# Altium schematic sheet Power.SchDoc
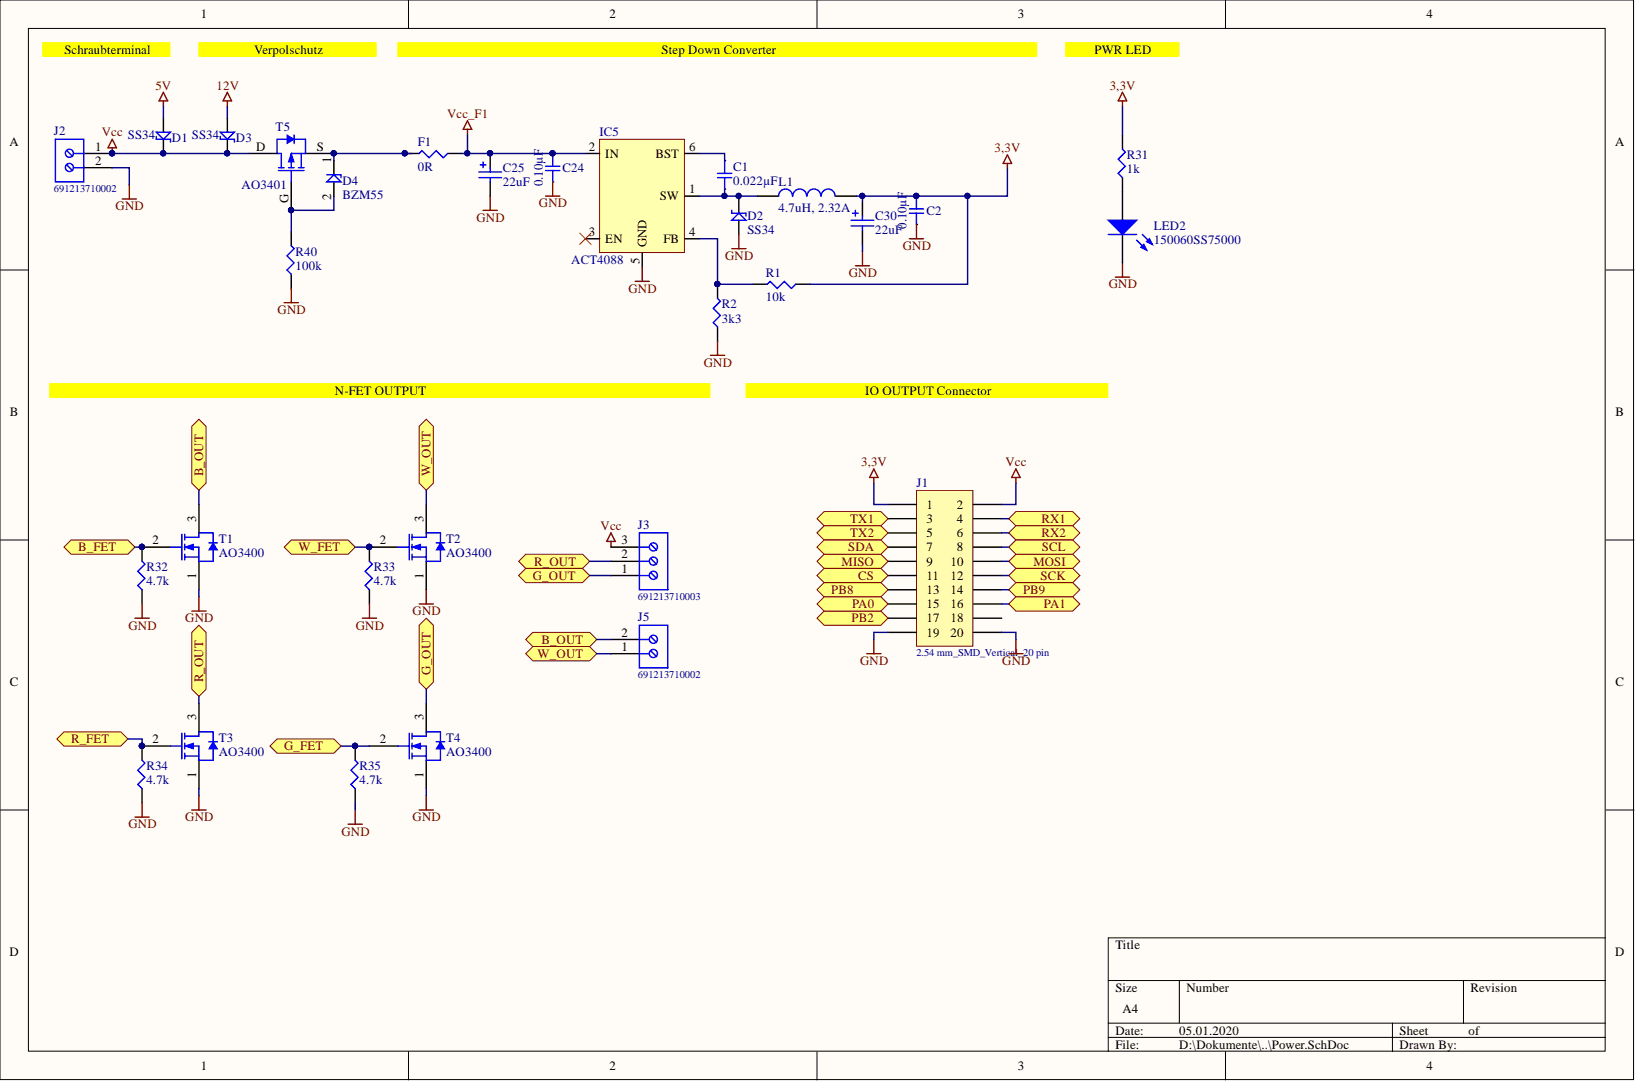
[diagram p0: Power.SchDoc]

## Parts
C1  0.022µF  [SMD-0603C]
C2  0.10µF  [SMD-0603C]
C24  0.10µF  [SMD-0603C]
C25  value 22uF  [WCAP-ASLI_4x5.5]  (hidden comment: 865080140001)
C30  value 22uF  [WCAP-ASLI_4x5.5]  (hidden comment: 865080140001)
D1  SS34  [B240A]
D2  SS34  [B240A]
D3  SS34  [B240A]
D4  BZM55  [SMD-0603-RES]
F1  0R  [SMD-0603-RES]
IC5  ACT4088  [SOT23-6]
J1  2.54 mm_SMD_Vertical_20 pin  [61002021121]
J2  691213710002  [691213710002]
J3  691213710003  [691213710003]
J5  691213710002  [691213710002]
L1  4.7uH, 2.32A  Rated Current: 2.32A  [WE-PD_7332]
LED2  150060SS75000  [0603_A]
R1  10k  [SMD-0603-RES]
R2  3k3  [SMD-0603-RES]
R31  1k  [SMD-0603-RES]
R32  4.7k  [SMD-0603-RES]
R33  4.7k  [SMD-0603-RES]
R34  4.7k  [SMD-0603-RES]
R35  4.7k  [SMD-0603-RES]
R40  100k  [SMD-0603-RES]
T1  AO3400  [SOT-23-3L]
T2  AO3400  [SOT-23-3L]
T3  AO3400  [SOT-23-3L]
T4  AO3400  [SOT-23-3L]
T5  AO3401  [SOT23]

## Ports
B_FET, B_OUT, CS, G_FET, G_OUT, MISO, MOSI, PA0, PA1, PB2, PB8, PB9, R_FET, R_OUT, RX1, RX2, SCK, SCL, SDA, TX1, TX2, W_FET, W_OUT

## Nets
3,3V: C2.1 C30.1 J1.1 L1.2 R1.1 R31.2
5V: D1.A
12V: D3.A
GND: C2.2 C24.2 C25.2 C30.2 D2.A IC5.5(GND) J1.19 J1.20 J2.2 LED2.1 R2.1 R32.1 R33.1 R34.1 R35.1 R40.1 T1.1 T2.1 T3.1 T4.1
Vcc: D1.K D3.K J1.2 J2.1 J3.3 T5.D
Vcc_F1: C24.1 C25.1 F1.1 IC5.2(IN)
B_FET: R32.2 T1.2 ; port B_FET
B_OUT: J5.2 T1.3 ; port B_OUT
CS: J1.11 ; port CS
G_FET: R35.2 T4.2 ; port G_FET
G_OUT: J3.1 T4.3 ; port G_OUT
MISO: J1.9 ; port MISO
MOSI: J1.10 ; port MOSI
PA0: J1.15 ; port PA0
PA1: J1.16 ; port PA1
PB2: J1.17 ; port PB2
PB8: J1.13 ; port PB8
PB9: J1.14 ; port PB9
R_FET: R34.2 T3.2 ; port R_FET
R_OUT: J3.2 T3.3 ; port R_OUT
RX1: J1.4 ; port RX1
RX2: J1.6 ; port RX2
SCK: J1.12 ; port SCK
SCL: J1.8 ; port SCL
SDA: J1.7 ; port SDA
TX1: J1.3 ; port TX1
TX2: J1.5 ; port TX2
W_FET: R33.2 T2.2 ; port W_FET
W_OUT: J5.1 T2.3 ; port W_OUT
NetC1_1: C1.1 IC5.6(BST)
NetC1_2: C1.2 D2.K IC5.1(SW) L1.1
NetD4_1: D4.1 F1.2 T5.S
NetD4_2: D4.2 R40.2 T5.G
NetIC5_4: IC5.4(FB) R1.2 R2.2
NetLED2_2: LED2.2 R31.1

Not connected: IC5 3(EN); J1 18

## Library data of the parts
C1 = GCJ188R71H223KA01D: CAP CER 0.022UF 50V X7R 0603; Manufacturer: Murata Electronics North America; @ qty: 0; Minimum Quantity: 1; Tolerance: ±10%; Unit Price (USD): 0.22; Applications: Automotive, Boardflex Sensitive; Component Kind: Standard; Datasheets: http://www.murata.com/~/media/webrenewal/support/library/catalog/products/capacitor/mlcc/c03e.ashx; Digi-Key Part Number: 490-5851-1-ND; Factory Stock: 0; Features: Soft Termination; Height - Seated (Max): -; Image: //media.digikey.com/Renders/Murata%20Renders/0603%28LQG18%29.jpg; Lead Spacing: -; Lead Style: -; Library Name: capacitor_murata_0603.IntLib; Mounting Type: Surface Mount, MLCC; Operating Temperature: -55°C ~ 125°C; Package / Case: 0603 (1608 Metric); Packaging: Cut Tape (CT); Part Number: GCJ188R71H223KA01D; Part Status: Active; Quantity Available: 7651; Ratings: AEC-Q200; Series: GCJ; Size / Dimension: 0.063" L x 0.031" W (1.60mm x 0.80mm); Temperature Coefficient: X7R; Thickness (Max): 0.031" (0.80mm); Value: 0.022µF; Voltage: 50V
C2 C24 = GCM188R71C104KA37J: CAP CER 0.1UF 16V X7R 0603; Manufacturer: Murata Electronics North America; @ qty: 0; Minimum Quantity: 1; Tolerance: ±10%; Unit Price (USD): 0.18; Applications: Automotive; Component Kind: Standard; Datasheets: http://www.murata.com/~/media/webrenewal/support/library/catalog/products/capacitor/mlcc/c03e.ashx; Digi-Key Part Number: 490-8015-1-ND; Factory Stock: 0; Features: -; Height - Seated (Max): -; Image: //media.digikey.com/Renders/Murata%20Renders/0603%28GCM188%29.jpg; Lead Spacing: -; Lead Style: -; Library Name: capacitor_murata_0603.IntLib; Mounting Type: Surface Mount, MLCC; Operating Temperature: -55°C ~ 125°C; Package / Case: 0603 (1608 Metric); Packaging: Cut Tape (CT); Part Number: GCM188R71C104KA37J; Part Status: Active; Quantity Available: 17844; Ratings: AEC-Q200; Series: GCM; Size / Dimension: 0.063" L x 0.031" W (1.60mm x 0.80mm); Temperature Coefficient: X7R; Thickness (Max): 0.035" (0.90mm); Value: 0.10µF; Voltage: 16V
C25 C30 = 865080140001: WCAP-ASLI Aluminum Electrolytic Capacitors, size Ф4x5.5mm, 6.3V DC, 22uF; Rated Voltage: 6.3V DC; Component Kind: Standard; ComponentLink1Description: Manufacturer; ComponentLink1URL: http://www.we-online.de; ComponentLink2Description: Datasheet; ComponentLink2URL: http://katalog.we-online.de/pbs/datasheet/865080140001.pdf; Library Name: ALTIUM-WCAP-ASLI-rev17a.IntLib; Life Cycle: 2000h; Operating Temperature: -55 °C up to +105 °C; Part Number: 865080140001; Published Date: June 2017; Size: Ф4x5.5mm; Publisher: Wurth Elektronik
D1-D3 = B240A: Shottkydiode; Component Kind: Standard; Library Name: MyLibs.SchLib
F1 R1 R2 R31 = ERJ-3EKF1001V: RES SMD 1K OHM 1% 1/10W 0603; Manufacturer: Panasonic Electronic Components; @ qty: 1500; Factory Stock: 0; Minimum Quantity: 1; Power (Watts): 0.1W, 1/10W; Temperature Coefficient: ±100ppm/°C; Unit Price (USD): 0.00379; Component Kind: Standard; Composition: Thick Film; Datasheets: http://industrial.panasonic.com/www-cgi/jvcr13pz.cgi?E+PZ+3+AOA0002+ERJ3EKF1001V+7+WW; Digi-Key Part Number: P1.00KHCT-ND; Failure Rate: -; Features: Automotive AEC-Q200; Height - Seated (Max): 0.022" (0.55mm); Image: //media.digikey.com/Photos/Panasonic%20Photos/MFG_ERJ-P6W%20Series.jpg; Library Name: Panasonic_ERJ_0603.IntLib; Number of Terminations: 2; Operating Temperature: -55°C ~ 155°C; Package / Case: 0603 (1608 Metric); Packaging: Cut Tape (CT); Part Number: ERJ-3EKF1001V; Part Status: Active; Quantity Available: 5218845; Series: ERJ; Size / Dimension: 0.063" L x 0.031" W (1.60mm x 0.80mm); Supplier Device Package: 0603; Tolerance: ±1%
  F1: Value: 0R
  R1: Value: 10k
  R2: Value: 3k3
  R31: Value: 1k
J1 = 61002021121: Pin Header WR-PHD, pitch 2.54 mm, SMD, Vertical, dual row, 20 pin; Contact Resistance: 20 mOhm; Insulation Resistance: >1000 MOhm; Pin Number: 20; Pitch: 2.54 mm; Rated Current: 3 A; Working Voltage: 250 VAC; Component Kind: Standard; ComponentLink1Description: Manufacturer; ComponentLink1URL: http://www.we-online.de; ComponentLink2Description: Datasheet; ComponentLink2URL: http://katalog.we-online.de/em/datasheet/6100xx21121.pdf; Library Name: Altium_WR-PHD_PIN_HEADER_2_54 (rev18a).IntLib; Mounting Technology: SMD; Operating Temperature: -40 to 105 degC; Orientation: Vertical; Part Number: 61002021121; Published Date: Jan. 2018; Row Number: Dual; Publisher: Wurth Elektronik
J2 J5 = 691213710002: Serie 213 - 5.00 mm Horizontal Entry Modular with RisingCage Clamp WR-TBL, 2 pin; Pin Number: 2; Pitch: 5.00 mm; Component Kind: Standard; ComponentLink1Description: Manufacturer; ComponentLink1URL: http://www.we-online.de; ComponentLink2Description: Datasheet; ComponentLink2URL: http://katalog.we-online.de/em/datasheet/6912137100xx.pdf; Library Name: Altium_TBL_RISING_CAGE_SYSTEM (rev18b).IntLib; Mounting Technology: THT; Operating Temperature: -40 to 105 degC; Packaging: Box; Part Number: 691213710002; Published Date: June 2018; Publisher: Wurth Elektronik
J3 = 691213710003: Serie 213 - 5.00 mm Horizontal Entry Modular with RisingCage Clamp WR-TBL, 3 pin; Pin Number: 3; Pitch: 5.00 mm; Component Kind: Standard; ComponentLink1Description: Manufacturer; ComponentLink1URL: http://www.we-online.de; ComponentLink2Description: Datasheet; ComponentLink2URL: http://katalog.we-online.de/em/datasheet/6912137100xx.pdf; Library Name: Altium_TBL_RISING_CAGE_SYSTEM (rev18b).IntLib; Mounting Technology: THT; Operating Temperature: -40 to 105 degC; Packaging: Box; Part Number: 691213710003; Published Date: June 2018; Publisher: Wurth Elektronik
L1 = 744778004: WE-PD SMT Power Inductor, size 7332, 4.7uH, 2.32A; DC Resistance max.: 60mOhm; Saturation Current: 4.2A; Self Resonant Frequency: 45MHz; Component Kind: Standard; ComponentLink1Description: Manufacturer; ComponentLink1URL: http://www.we-online.de; ComponentLink2Description: Datasheet; ComponentLink2URL: https://katalog.we-online.com/pbs/datasheet/744778004.pdf; Inductance: 4.7uH; Library Name: ALTIUM-WE-PD-rev19b.IntLib; Mounting Technology: SMT; Operating Temperature: -40 to +125degC; Packaging: Tape and Reel; Part Number: 744778004; Published Date: April 2019; Size: 7332; Publisher: Wurth Elektronik
LED2 = 150060SS75000: SMD mono-color Chip LED, WL-SMCW, Super Red; Color: Super Red; Component Kind: Standard; ComponentLink1Description: Manufacturer URL; ComponentLink1URL: http://www.we-online.com; ComponentLink2Description: Datasheet; ComponentLink2URL: http://katalog.we-online.com/led/datasheet/150060SS75000.pdf; PackageReference: 0603_A; PartNumber: 150060SS75000; Library Name: WL-SMCW SMD chip LED top view mono-color waterclear.IntLib
R32-R35 R40 = ERJ-3EKF4701V: RES SMD 4.7K OHM 1% 1/10W 0603; Manufacturer: Panasonic Electronic Components; @ qty: 1500; Factory Stock: 0; Minimum Quantity: 1; Power (Watts): 0.1W, 1/10W; Temperature Coefficient: ±100ppm/°C; Unit Price (USD): 0.00379; Component Kind: Standard; Composition: Thick Film; Datasheets: http://industrial.panasonic.com/www-cgi/jvcr13pz.cgi?E+PZ+3+AOA0002+ERJ3EKF4701V+7+WW; Digi-Key Part Number: P4.70KHCT-ND; Failure Rate: -; Features: Automotive AEC-Q200; Height - Seated (Max): 0.022" (0.55mm); Image: //media.digikey.com/Photos/Panasonic%20Photos/MFG_ERJ-P6W%20Series.jpg; Library Name: Panasonic_ERJ_0603.IntLib; Number of Terminations: 2; Operating Temperature: -55°C ~ 155°C; Package / Case: 0603 (1608 Metric); Packaging: Cut Tape (CT); Part Number: ERJ-3EKF4701V; Part Status: Active; Quantity Available: 999380; Series: ERJ; Size / Dimension: 0.063" L x 0.031" W (1.60mm x 0.80mm); Supplier Device Package: 0603; Tolerance: ±1%
  R32-R35: Value: 4.7k
  R40: Value: 100k
T1-T4 = AO3400: AO3400 MOSFET 30V; Component Kind: Standard; Library Name: MyLibs.SchLib
T5 = AO3401: AO3401 MOSFET 30V; Component Kind: Standard; Library Name: MyLibs.SchLib
D4 = BZM55: Component Kind: Standard; Library Name: MyLibs.SchLib
IC5 = ACT4088: Component Kind: Standard; Library Name: MyLibs.SchLib
